# Altium schematic sheet Auxiliary.SchDoc
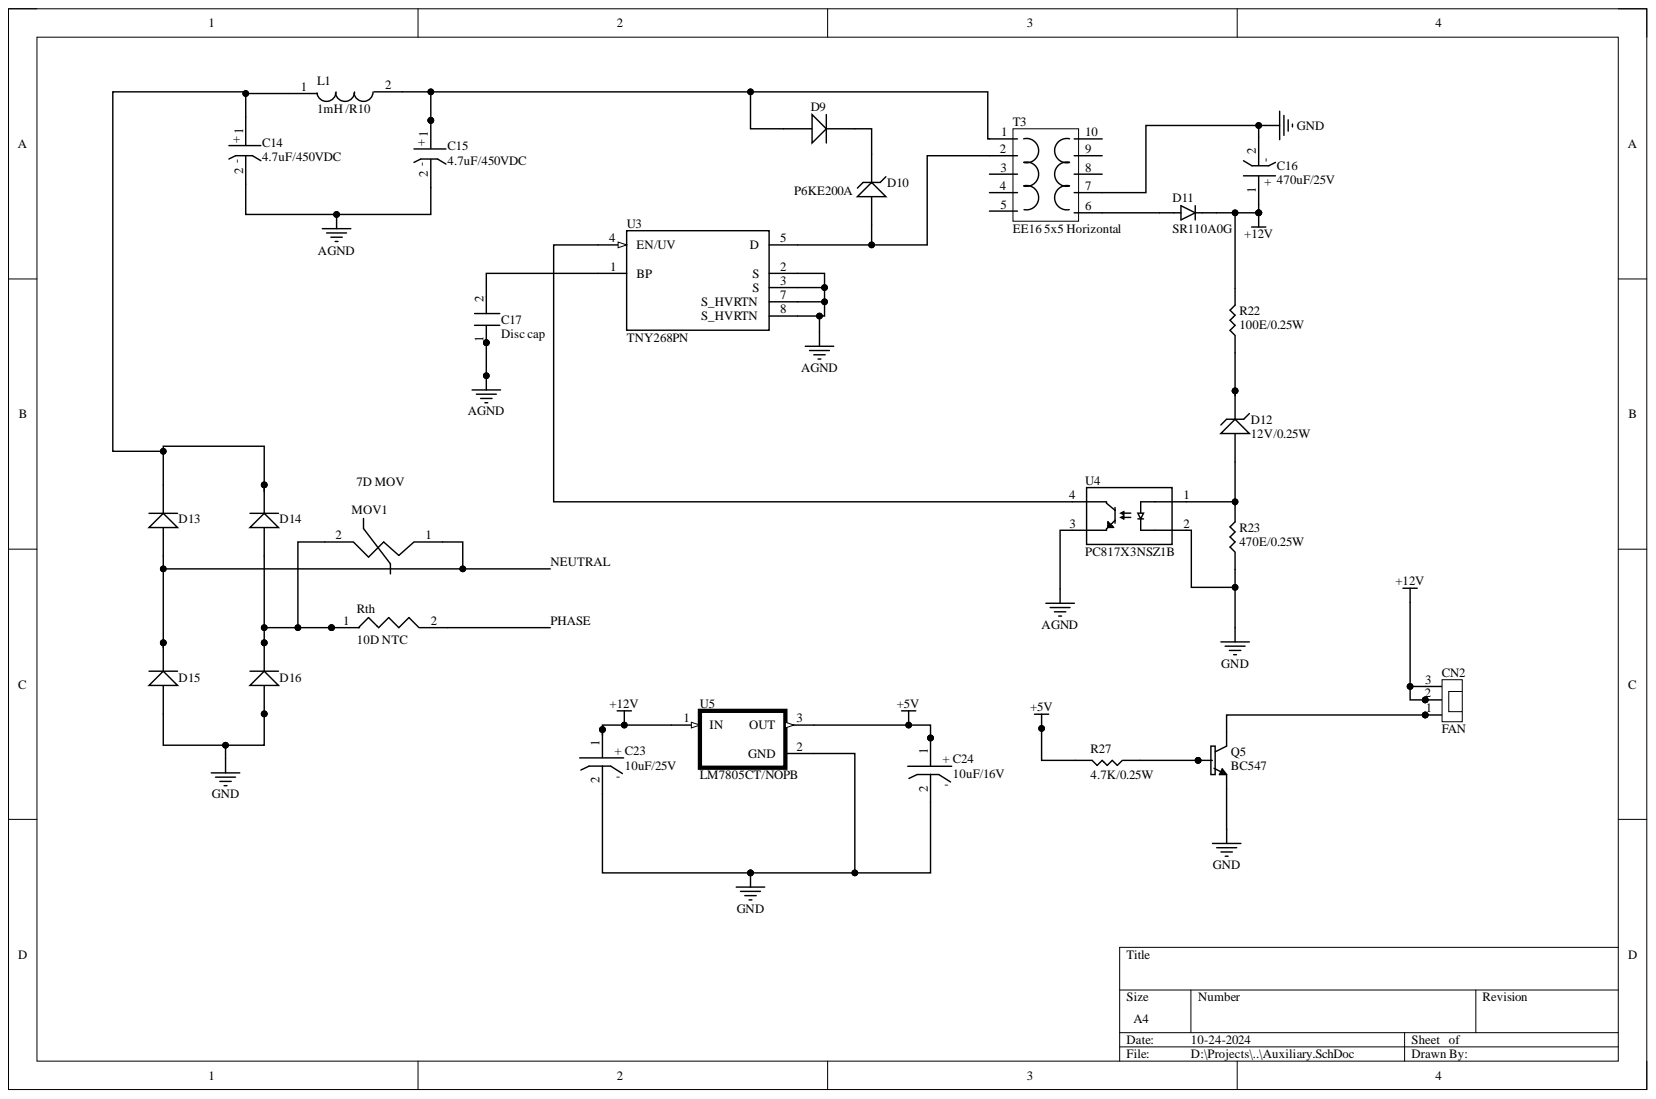
[diagram p0: Auxiliary.SchDoc]

## Parts
C14  4.7uF/450VDC  [8mm Dia Eletrolytic Cap]
C15  4.7uF/450VDC  [8mm Dia Eletrolytic Cap]
C16  470uF/25V  [8mm Dia Eletrolytic Cap]
C17  Disc cap  [Disc cap]
C23  10uF/25V  [Electrolytic cap_6mm]
C24  10uF/16V  [Electrolytic cap_6mm]
CN2  FAN  [2.5mm 3 pin male header]
D9  Diode  (value not drawn)
D10  BV: P6KE200A  (hidden comment: Zener Diode)
D11  SR110A0G  [DIOAD1036W78L470D235]
D12  BV: 12V/0.25W  (hidden comment: Zener Diode)
D13  Diode  (value not drawn)
D14  Diode  (value not drawn)
D15  Diode  (value not drawn)
D16  Diode  (value not drawn)
L1  1mH /R10  [R10 Inductor Footprint]
MOV1  7D MOV  [5mm pitch 4mm thick component]
Q5  BC547  [TO92]
R22  100E/0.25W  [0.25W TH Resistor]
R23  470E/0.25W  [0.25W TH Resistor]
R27  4.7K/0.25W  [0.25W TH Resistor]
Rth  10D NTC  [5mm pitch 4mm thick component]
T3  EE16 5x5 Horizontal  [EE16 5x5 Horizontal]
U3  TNY268PN  [DIP762W46P254L957H406Q7]
U4  PC817X3NSZ1B  [DIP762W50P254L495H455Q4]
U5  LM7805CT/NOPB  [TO254P1054X470X2600-3]

## Nets
+5V: C24.1 R27.2 U5.3
+12V: C16.1 C23.1 CN2.2 CN2.3 D11.C R22.2 U5.1
AGND: C14.2 C15.2 C17.1 U3.2(S) U3.3(S) U3.7(S_HVRTN) U3.8(S_HVRTN) U4.3
GND: C16.2 C23.2 C24.2 D15.1 D16.1 Q5.1 R23.1 T3.7 U4.2 U5.2
NEUTRAL: D13.1 D15.2 MOV1.1
PHASE: Rth.2
NetC14_1: C14.1 D13.2 D14.2 L1.1
NetC15_1: C15.1 D9.1 L1.2 T3.1
NetC17_2: C17.2 U3.1(BP)
NetCN2_1: CN2.1 Q5.3
NetD9_2: D9.2 D10.2
NetD10_1: D10.1 T3.2 U3.5(D)
NetD11_A: D11.A T3.6
NetD12_1: D12.1 R23.2 U4.1
NetD12_2: D12.2 R22.1
NetD14_1: D14.1 D16.2 MOV1.2 Rth.1
NetQ5_2: Q5.2 R27.1
NetU3_4: U3.4(EN/UV) U4.4

Not connected: T3 3 4 5 8 9 10

## Library data of the parts
C14-C16 = 8 Dia Cap: Component Kind: Standard; Library Name: Custom1.IntLib
C23 C24 = 6mm Electolytic cap: Component Kind: Standard; Library Name: Custom1.IntLib
CN2 = 2.5mm 3 pin male header: Component Kind: Standard; Library Name: Custom1.IntLib
D9 D13-D16 = Diode: AF: 1; BV: 0; BV_MAX: 1e99; EG: 1.11; FC: 0.5; IBV: 0.001; IS: 1e-14; MJSW: 0.33; NTUN: 30; SimulationGenericComponent: True; TNOM: 27; XTI: 3; CJO: 0; CJP: 0; Component Kind: Standard; CTA: 0; CTP: 0; Description: Diode; FCS: 0.5; FV_MAX: 1e99; IKF: 0; IKR: 0; ISR: 1e-14; JSW: 0; JTUN: 0; JTUNSW: 0; KEG: 1; KF: 0; LEVEL: 1; Library Name: Simulation Generic Components.IntLib; N: 1; NBV: 1; NR: 1; NS: 1; PHP: 1; RS: 0; Simulation: diode; TCV: 0; TLEV: 0; TLEVC: 0; TM1: 0; TM2: 0; TPB: 0; TPHP: 0; TRS: 0; TRS2: 0; TT: 0; TTT1: 0; TTT2: 0; VJ: 1; XTITUN: 3
D10 D12 = Zener Diode: AF: 1; BV_MAX: 1e99; CJO: 0; EG: 1.11; FC: 0.5; IBV: 0.001; IS: 1e-14; MJSW: 0.33; NTUN: 30; SimulationGenericComponent: True; TNOM: 27; XTI: 3; CJP: 0; Component Kind: Standard; CTA: 0; CTP: 0; Description: Zener Diode; FCS: 0.5; FV_MAX: 1e99; IKF: 0; IKR: 0; ISR: 1e-14; JSW: 0; JTUN: 0; JTUNSW: 0; KEG: 1; KF: 0; LEVEL: 1; Library Name: Simulation Generic Components.IntLib; N: 1; NBV: 1; NR: 1; NS: 1; PHP: 1; RS: 0; Simulation: diode; TCV: 0; TLEV: 0; TLEVC: 0; TM1: 0; TM2: 0; TPB: 0; TPHP: 0; TRS: 0; TRS2: 0; TT: 0; TTT1: 0; TTT2: 0; VJ: 1; XTITUN: 3
D11 = SR110A0G: 1A, 100V, Planar Schottky; MF: Taiwan Semiconductor; Check_prices: https://www.snapeda.com/parts/SR110%20A0G/Taiwan+Semiconductor/view-part/?ref=eda; Component Kind: Standard; Library Name: SR110A0G.IntLib; MP: SR110 A0G; Package: DO-41 Taiwan Semiconductor; SnapEDA_Link: https://www.snapeda.com/parts/SR110%20A0G/Taiwan+Semiconductor/view-part/?ref=snap
L1 = R10 Drum Inductor: Component Kind: Standard; Library Name: Custom1.IntLib
Q5 = BC547*: Trans GP BJT NPN 45V 0.1A 500mW 3-Pin TO-92 T/R; MF: ON Semiconductor; Check_prices: https://www.snapeda.com/parts/BC547/Onsemi/view-part/?ref=eda; Component Kind: Standard; Library Name: BC547_.IntLib; MP: BC547; Package: None; SnapEDA_Link: https://www.snapeda.com/parts/BC547/Onsemi/view-part/?ref=snap
R22 R23 R27 = 0.25W TH resistor: Component Kind: Standard; Library Name: Custom1.IntLib
Rth = NTC 10D: Component Kind: Standard; Library Name: Custom1.IntLib
U3 = TNY268PN: Converter Offline Flyback Topology 132kHz 8-PDIP-B; MF: Power Integrations; Check_prices: https://www.snapeda.com/parts/TNY268PN/Power+Integrations/view-part/?ref=eda; Component Kind: Standard; Library Name: TNY268PN.IntLib; MP: TNY268PN; Package: DIP-8 Power Integrations; SnapEDA_Link: https://www.snapeda.com/parts/TNY268PN/Power+Integrations/view-part/?ref=snap
U4 = PC817X3NSZ1B: Optoisolator Transistor Output - 1 Channel 4-DIP; MF: Sharp Microelectronics; Availability: In Stock; Check_prices: https://www.snapeda.com/parts/PC817X3NSZ1B/Sharp+Microelectronics/view-part/?ref=eda; Component Kind: Standard; Library Name: PC817X3NSZ1B.IntLib; MP: PC817X3NSZ1B; Package: DIP-4 Sharp Microelectronics; Price: None; Purchase-URL: https://pricing.snapeda.com/search/part/PC817X3NSZ1B/?ref=eda; SnapEDA_Link: https://www.snapeda.com/parts/PC817X3NSZ1B/Sharp+Microelectronics/view-part/?ref=snap
U5 = LM7805CT/NOPB: Linear Voltage Regulator IC Positive Fixed 1 Output 1.5A TO-220-3; MF: ON Semiconductor; Availability: In Stock; Check_prices: https://www.snapeda.com/parts/LM7805CT/Onsemi/view-part/?ref=eda; Component Kind: Standard; Library Name: LM7805CT_NOPB.IntLib; MP: LM7805CT; Package: TO-220-3 ON Semiconductor; Price: None; Purchase-URL: https://pricing.snapeda.com/search/part/LM7805CT/?ref=eda; SnapEDA_Link: https://www.snapeda.com/parts/LM7805CT/Onsemi/view-part/?ref=snap
C17 = Disc cap: Component Kind: Standard; Library Name: Custom1.IntLib
MOV1 = 7D MOV: Component Kind: Standard; Library Name: Custom1.IntLib
T3 = EE16 5x5 Horizontal: Component Kind: Standard; Library Name: Custom1.IntLib
